# Altium schematic sheet Power.SchDoc(Power)
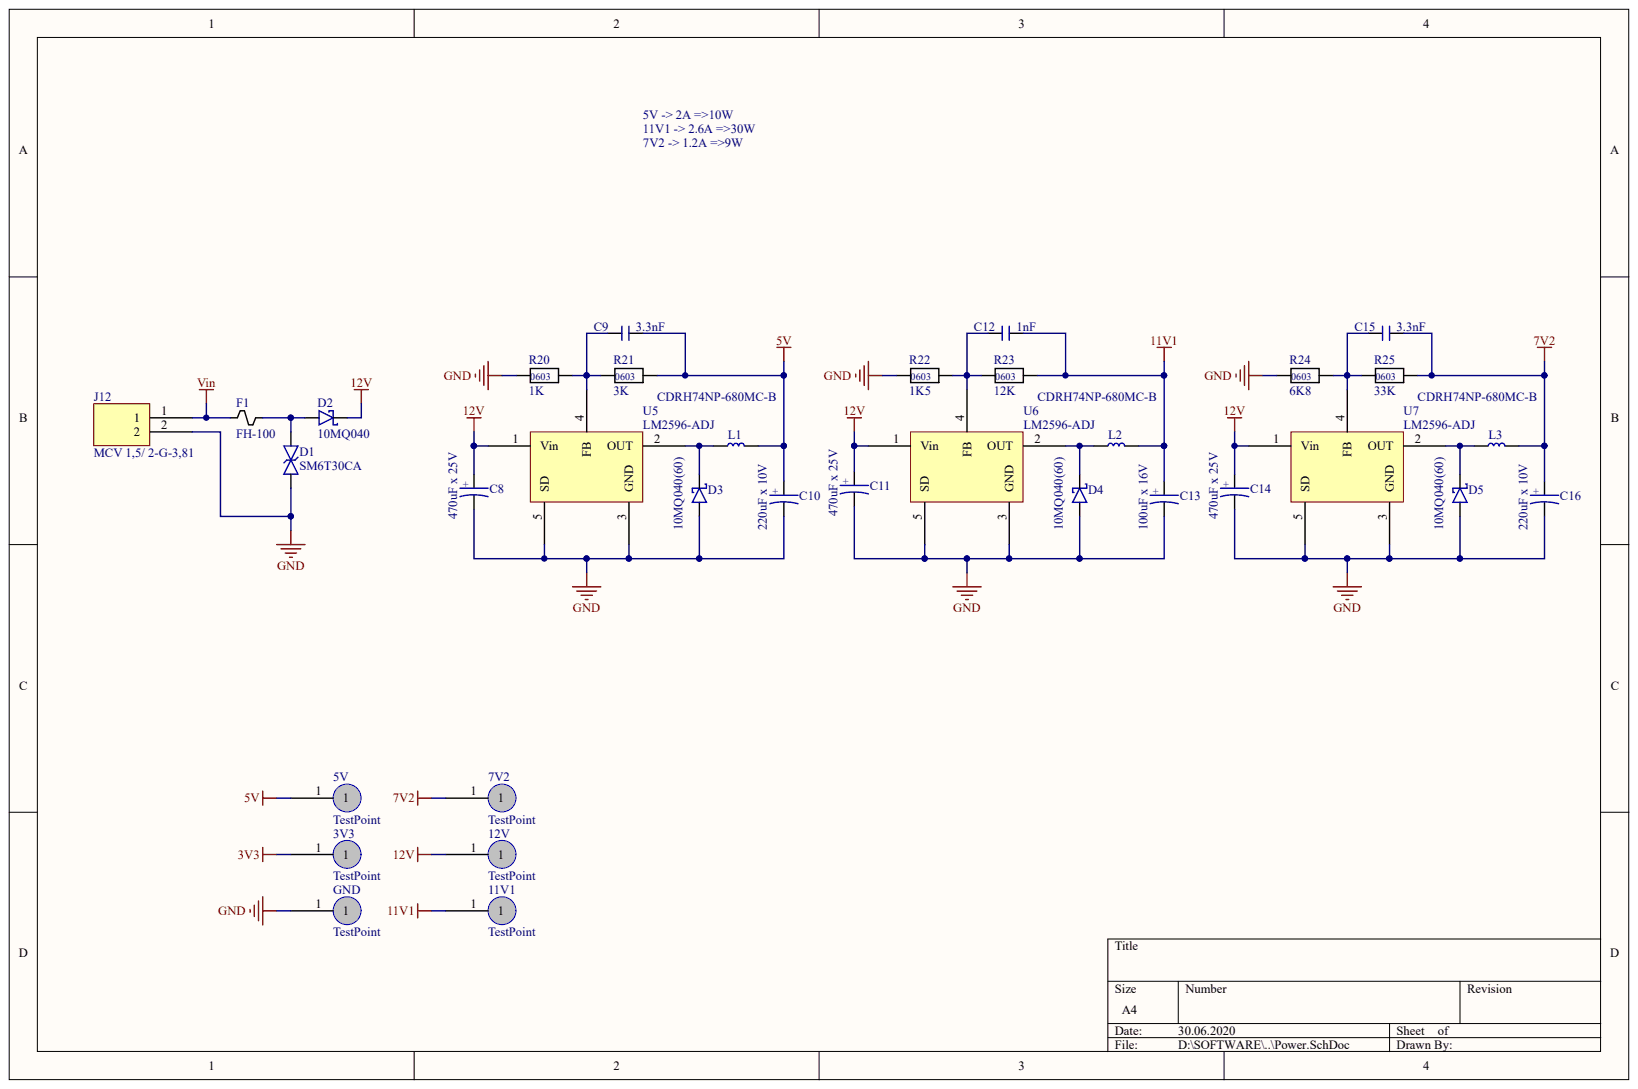
[diagram p0: Power.SchDoc]

## Parts
3V3  TestPoint
5V  TestPoint
7V2  TestPoint
11V1  TestPoint
12V  TestPoint
C8  470uF x 25V
C9  3.3nF
C10  220uF x 10V
C11  470uF x 25V
C12  1nF
C13  100uF x 16V
C14  470uF x 25V
C15  3.3nF
C16  220uF x 10V
D1  SM6T30CA
D2  10MQ040
D3  10MQ040(60)
D4  10MQ040(60)
D5  10MQ040(60)
F1  FH-100
GND  TestPoint
J12  MCV 1,5/ 2-G-3,81
L1  CDRH74NP-680MC-B
L2  CDRH74NP-680MC-B
L3  CDRH74NP-680MC-B
R20  1K
R21  3K
R22  1K5
R23  12K
R24  6K8
R25  33K
U5  LM2596-ADJ
U6  LM2596-ADJ
U7  LM2596-ADJ

## Nets
3V3: 3V3.1
5V: 5V.1 C9.1 C10.1 L1.2 R21.2
7V2: 7V2.1 C15.1 C16.1 L3.2 R25.2
11V1: 11V1.1 C12.1 C13.1 L2.2 R23.2
12V: 12V.1 C8.1 C11.1 C14.1 D2.2 U5.1(Vin) U6.1(Vin) U7.1(Vin)
GND: C8.2 C10.2 C11.2 C13.2 C14.2 C16.2 D1.2 D3.1 D4.1 D5.1 GND.1 J12.2 R20.1 R22.1 R24.1 U5.3(GND) U5.5(SD) U6.3(GND) U6.5(SD) U7.3(GND) U7.5(SD)
VIN: F1.1 J12.1
NetC9_2: C9.2 R20.2 R21.1 U5.4(FB)
NetC12_2: C12.2 R22.2 R23.1 U6.4(FB)
NetC15_2: C15.2 R24.2 R25.1 U7.4(FB)
NetD1_1: D1.1 D2.1 F1.2
NetD3_2: D3.2 L1.1 U5.2(OUT)
NetD4_2: D4.2 L2.1 U6.2(OUT)
NetD5_2: D5.2 L3.1 U7.2(OUT)
